# Altium schematic sheet CAN-DB9-adapter.SchDoc(CAN-DB9-adapter)
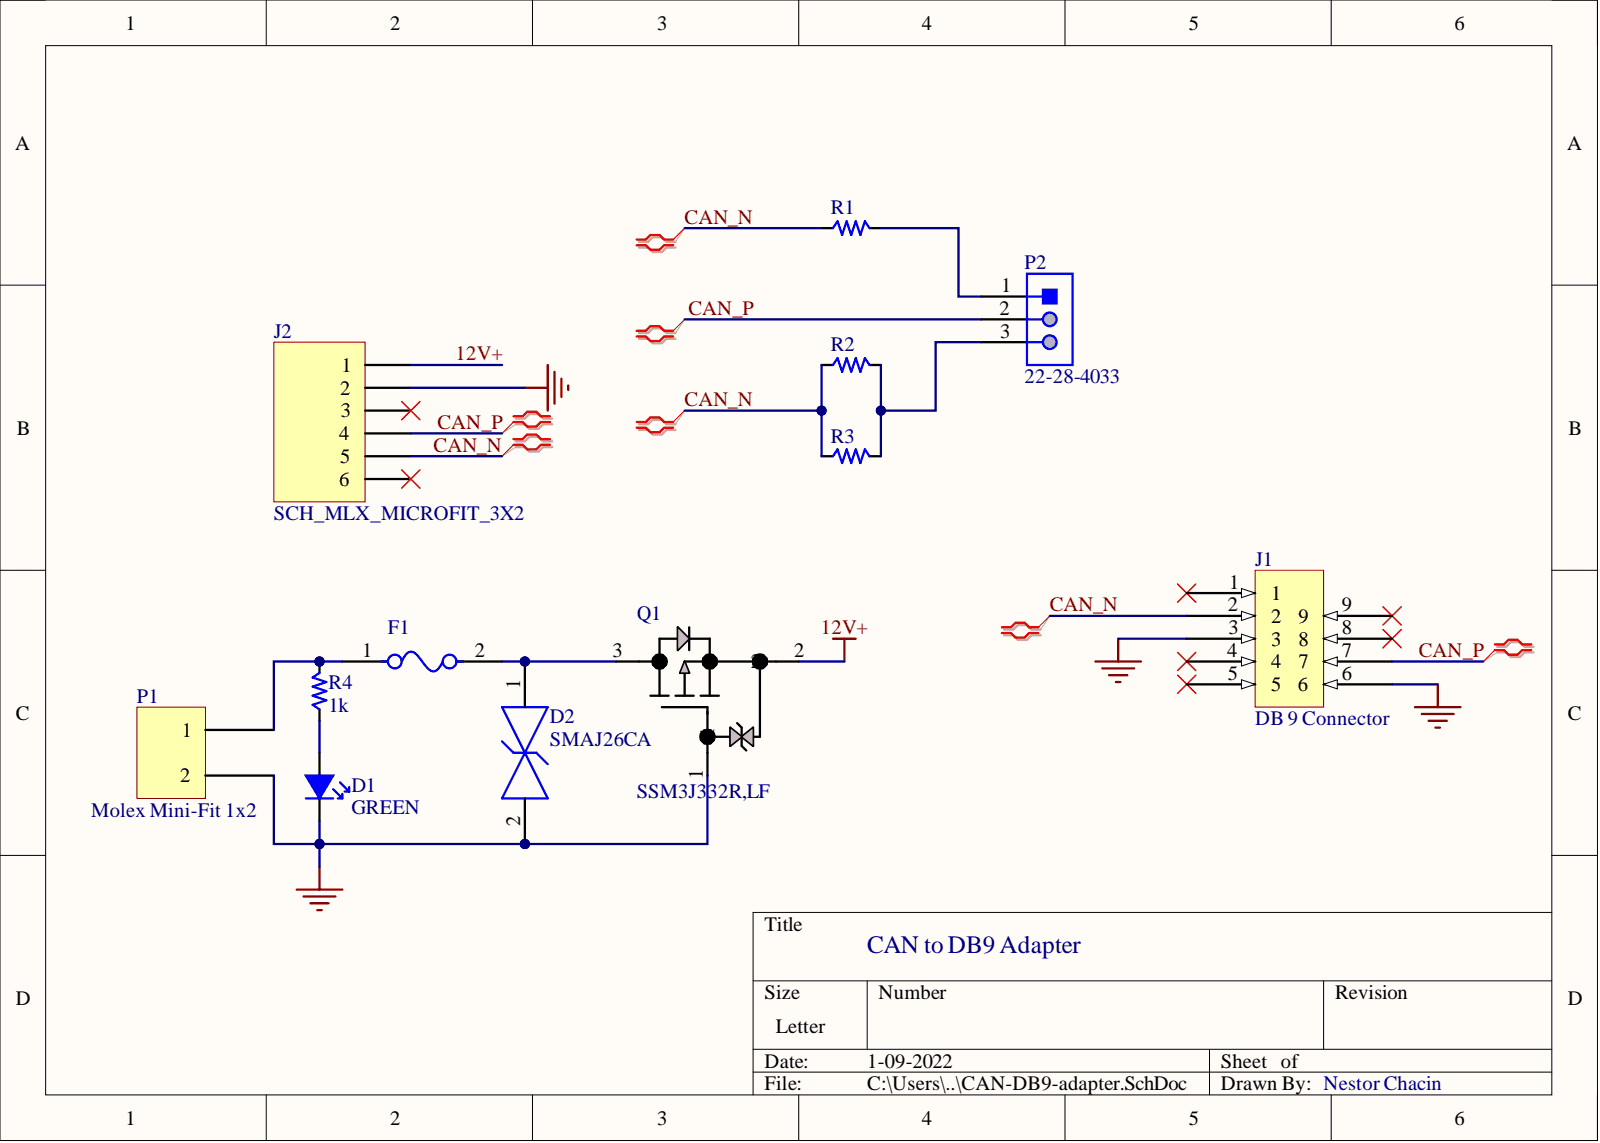
[diagram p0: CAN-DB9-adapter.SchDoc]

## Parts
D1  Color: GREEN  [SMD_0603_LED]  (hidden comment: D060-000G2-00)
D2  SMAJ26CA  [FP-SMAJ-CA-MFG]
F1  0154008.DR  [FP-0154-MFG]  (value not drawn)
J1  DB 9 Connector  [New footprint 1]
J2  SCH_MLX_MICROFIT_3X2  [PCB_MLX_MICROFIT_3X2]
P1  Molex Mini-Fit 1x2  [Molex Mini-Fit Jr 2x1]
P2  22-28-4033  [MOLX-22-28-4033_V]
Q1  SSM3J332R,LF  [SOT-23F_TOS]
R1  SCH_GENERIC_RESISTOR_0603  [PCB_GENERIC_SMD_0603]  (value not drawn)
R2  SCH_GENERIC_RESISTOR_0603  [PCB_GENERIC_SMD_0603]  (value not drawn)
R3  SCH_GENERIC_RESISTOR_0603  [PCB_GENERIC_SMD_0603]  (value not drawn)
R4  Resistance: 1k  [PCB_GENERIC_SMD_0603]  (hidden comment: SCH_GENERIC_RESISTOR_0603)

## Nets
GND: D1.1 D2.2 J1.3 J1.6 J2.2 P1.2 Q1.1
12V+: J2.1 Q1.2
CAN_N: J1.2 J2.5 R1.1 R2.1 R3.1
CAN_P: J1.7 J2.4 P2.2
NetD1_2: D1.2 R4.1
NetD2_1: D2.1 F1.2 Q1.3
NetF1_1: F1.1 P1.1 R4.2
NetP2_1: P2.1 R1.2
NetP2_3: P2.3 R2.2 R3.2

Not connected: J1 1 4 5 8 9; J2 3 6

## Library data of the parts
D1 = CMP-073-0000-1: LED 0603 GREEN 3.2Vf 20mA; Component Kind: Standard; Component Type: Standard; Design Item ID: D060-000G2-00; Pin Count: 2; Source: LED-0603.SchLib; Library Name: University of Calgary Solar Car Team
D2 = CMP-00009-1: Transient Voltage Suppressor, Tvs, Smaj Series, Bidirectional, 26 V, Do-214Ac, 2, 28.9 V Rohs Compliant: Yes; Breakdown Voltage: 28.9V; Clamping Voltage: 42.1V; Diode Type: ZENER; Leakage Current: 1uA; Max Breakdown Voltage: 31.9V; Max Power Dissipation: 3.3W; Max Surge Current: 9.5A; Number of Bidirectional Channels: 1; Operating Supply Voltage: 26V; Peak Pulse Power: 400W; Power Line Protection: No; Test Current: 1mA; Case/Package: DO-214AC; Clamping Voltage-Max: 42.1V; Component Kind: Standard; Direction: Bidirectional; Height: 2.29mm; Lead Free: Lead Free; Length: 5.28mm; Max Operating Temperature: 150°C; Min Breakdown Voltage: 28.9V; Min Operating Temperature: -55°C; Mount: Surface Mount; Number of Channels: 1; Number of Elements: 1; Number of Pins: 2; Package Quantity: 5000; Packaging: Tape and Reel; Peak Pulse Current: 9.5A; Polarity: Bidirectional; Power Dissipation: 400W; REACH SVHC: No SVHC; Resistor Type: Zener; Reverse Breakdown Voltage: 28.9V; Reverse Standoff Voltage: 26V; RoHS Compliant: Yes; Series: SMAJ; Termination: SMD/SMT; Voltage Rating (DC): 26V; Width: 2.79mm; Library Name: University of Calgary Solar Car Team
F1 = CMP-08605-000078-1: FUSE BRD MNT 8A 125VAC/VDC 2SMD; Manufacturer: Littelfuse Inc; Fuse Blow Speed: Fast; Voltage Rating: 125V; Automotive: No; Category: Fuse; Component Kind: Standard; ComponentLink2Description: Datasheet; ComponentLink2URL: https://www.littelfuse.com/~/media/electronics/datasheets/fuses/littelfuse_fuse_154_154t_154l_154tl_datasheet.pdf.pdf; Device Class L1: Circuit Protection; Device Class L2: Fuses; Device Class L3: unset; Digikey Description: FUSE BRD MNT 8A 125VAC/VDC 2SMD; Fuse Current: 8A; Height: 3.81mm; Lead Free: Yes; Library Name: Altium Content Vault; Manufacturer Part Number: 0154008.DR; Mouser Description: Surface Mount Fuses Fuseblock w/ fuse 8A OMNI BLOK 154; Octopart: https://octopart.com/0154008.dr-littelfuse-565874; Package: 0154; RoHS: TRUE; Temperature: -55°C to +125°C; Temperature Range High: +125°C; Temperature Range Low: -55°C
J1 = CMP-00006-3: Right angle DB 9 connector for CAN communications; Component Kind: Standard; Library Name: University of Calgary Solar Car Team
J2 = CMP-064-0016-3: Component Kind: Standard; Design Item ID: SCH_MLX_MICROFIT_3X2; Pin Count: 6; Source: Molex Microfit 3.0 3x2.SchLib; Library Name: University of Calgary Solar Car Team
P1 = CMP-064-0008-1: Component Kind: Standard; Design Item ID: Molex Mini-Fit 1x2; Pin Count: 2; Source: Molex Mini-Fit Jr 1x2.SchLib; Library Name: University of Calgary Solar Car Team
P2 = CMP-1676-00001-5: Male Header, Pitch 2.54 mm, 1 x 3 Position, Height 8.38 mm, Tail Length 3.18 mm, RoHS, Bulk; Manufacturer: Molex; Current Rating: 4 A; Rows: 1; Voltage Rating: 250 V; Colour: Black; Component Kind: Standard; ComponentLink1Description: Manufacturer URL; ComponentLink1URL: http://www.molex.com/; ComponentLink2Description: Datasheet; ComponentLink2URL: http://www.molex.com/pdm_docs/sd/022284033_sd.pdf; Contact Finish: Gold; Contact Material: Brass; Contact Plating: Gold; DatasheetVersion: Rev. G, 01/2012; Height: 8.38 mm; Lead Pitch: 0.1 inch; Length: 7.62 mm; Library Name: Altium Content Vault; Max Operating Temperature: 75 degC; Min Operating Temperature: 0 degC; Mount: Through Hole; Mounting Technology: Through Hole; Package Quantity: 1; PackageDescription: Male Header, Pitch 2.54 mm, 1 x 3 Position, Height 8.38 mm, Tail Length 3.18 mm; PackageReference: 22-28-4033; Packaging: Bulk; Pins: 3; REACH SVHC: Unknown; RoHSCompliant: true
Q1 = CMP-157-000001-3: No Description Available; Mfr_Name: Toshiba Electronic Devices & Storage Co.; Type: DEV; Component Kind: Standard; Design Item ID: SSM3J332R,LF; Manufacturer_Part_Number: SSM3J332R,LF; RefDes: RefDes; Source: SSM3J332R,LF.SchLib; Copyright: Copyright (C) 2021 Ultra Librarian. All rights reserved.; Library Name: University of Calgary Solar Car Team
R1-R4 = CMP-085-0011-3: Generic SMD resistor 0603; Component Kind: Standard; Component Type: Standard; Design Item ID: SCH_GENERIC_RESISTOR_0603; Pin Count: 2; Source: Generic 0603 Resistor.SchLib; Library Name: University of Calgary Solar Car Team
